# Altium schematic sheet Extra8_i2c.SchDoc
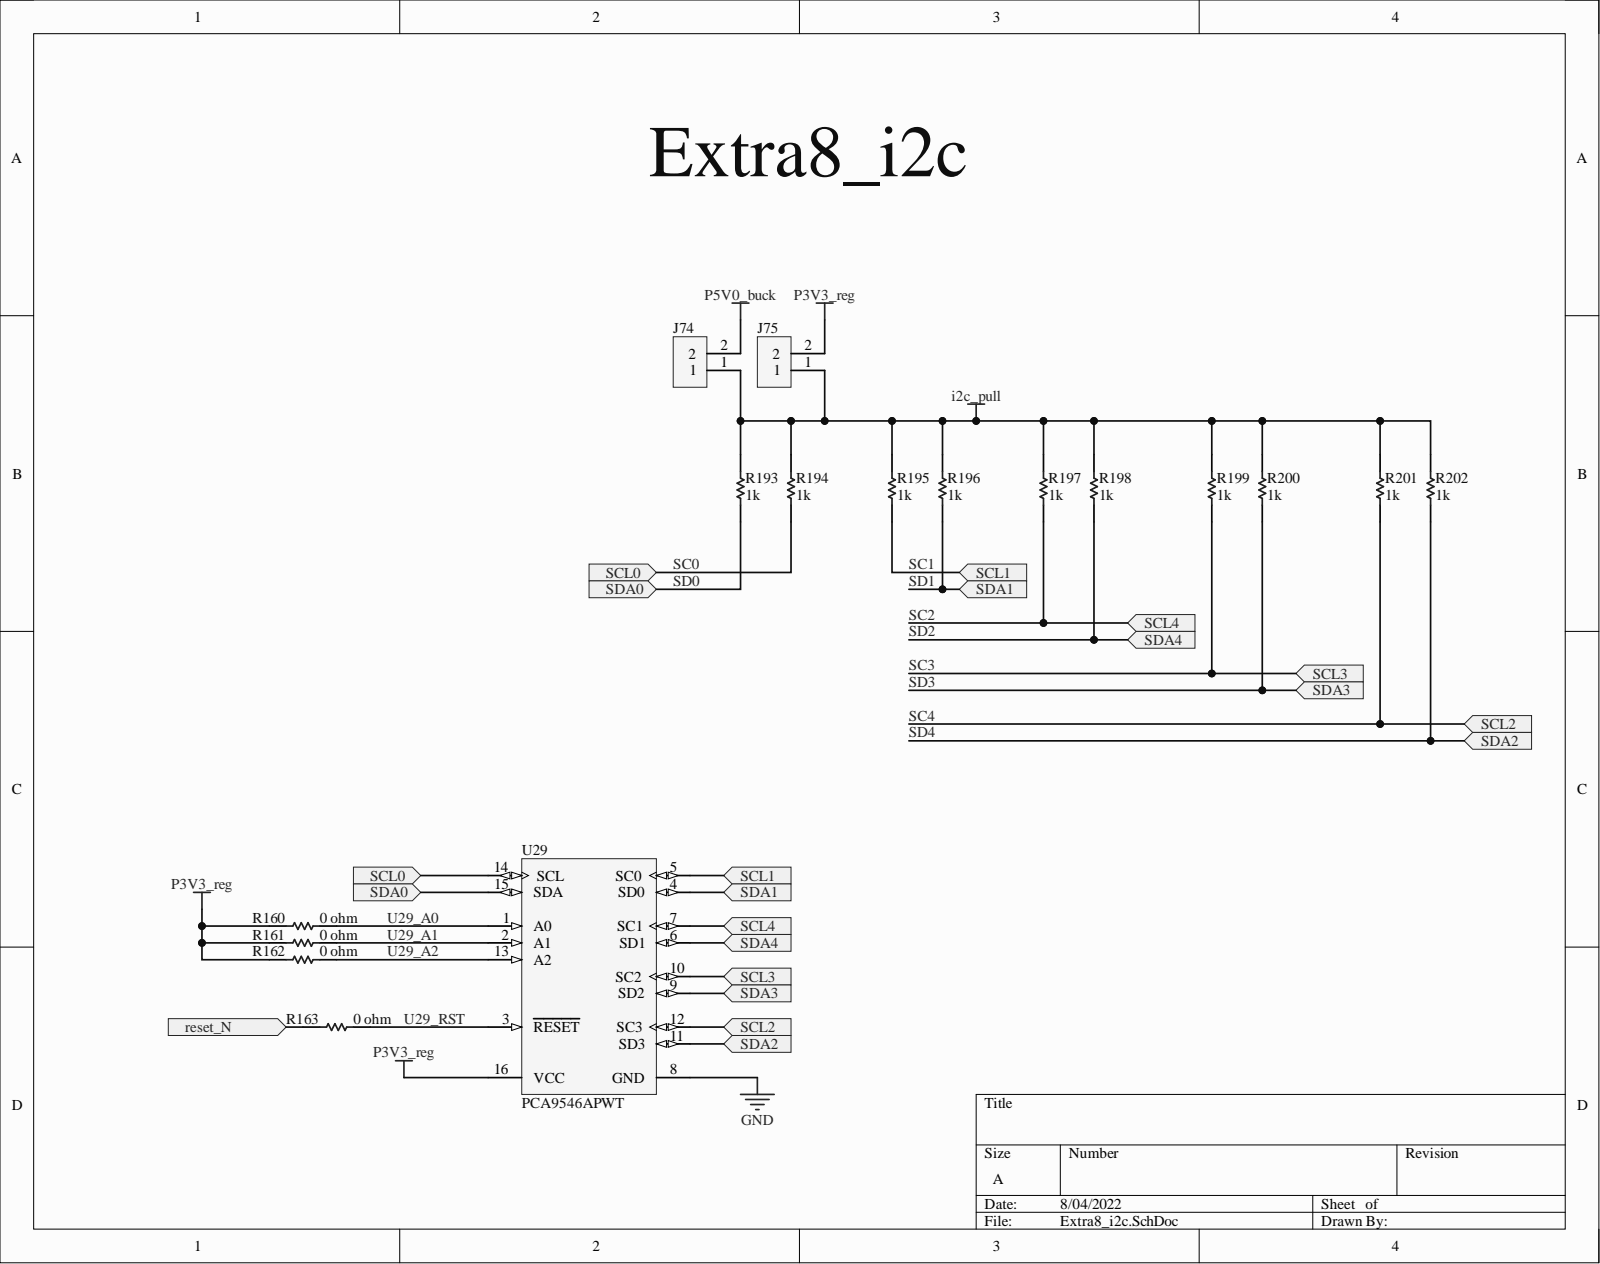
[diagram p0: Extra8_i2c.SchDoc]

## Parts
J74  (no value)  [PCB-omgsbkhp90n7lpri88og-1]
J75  (no value)  [PCB-omgsbkhp90n7lpri88og-1]
R160  0 ohm  [RESC2013X50X30LL20T20]
R161  0 ohm  [RESC2013X50X30LL20T20]
R162  0 ohm  [RESC2013X50X30LL20T20]
R163  0 ohm  [RESC2013X50X30LL20T20]
R193  1k  [PCB-inhgnewug1oc5mowfht0-1]
R194  1k  [PCB-inhgnewug1oc5mowfht0-1]
R195  1k  [PCB-inhgnewug1oc5mowfht0-1]
R196  1k  [PCB-inhgnewug1oc5mowfht0-1]
R197  1k  [PCB-inhgnewug1oc5mowfht0-1]
R198  1k  [PCB-inhgnewug1oc5mowfht0-1]
R199  1k  [PCB-inhgnewug1oc5mowfht0-1]
R200  1k  [PCB-inhgnewug1oc5mowfht0-1]
R201  1k  [PCB-inhgnewug1oc5mowfht0-1]
R202  1k  [PCB-inhgnewug1oc5mowfht0-1]
U29  PCA9546APWT  [PW16_M]

## Ports
reset_N, SCL0, SCL1, SCL2, SCL3, SCL4, SDA0, SDA1, SDA2, SDA3, SDA4

## Nets
GND: U29.8(GND)
i2c_pull: J74.1 J75.1 R193.2 R194.2 R195.2 R196.2 R197.2 R198.2 R199.2 R200.2 R201.2 R202.2
P3V3_reg: J75.2 R160.1 R161.1 R162.1 U29.16(VCC)
P5V0_buck: J74.2
reset_N: R163.1 ; port reset_N
SC0: R194.1 U29.14(SCL) ; port SCL0
SC1: R195.1 U29.5(SC0) ; port SCL1
SC2: R197.1 U29.7(SC1) ; port SCL4
SC3: R199.1 U29.10(SC2) ; port SCL3
SC4: R201.1 U29.12(SC3) ; port SCL2
SD0: R193.1 U29.15(SDA) ; port SDA0
SD1: R196.1 U29.4(SD0) ; port SDA1
SD2: R198.1 U29.6(SD1) ; port SDA4
SD3: R200.1 U29.9(SD2) ; port SDA3
SD4: R202.1 U29.11(SD3) ; port SDA2
U29_A0: R160.2 U29.1(A0)
U29_A1: R161.2 U29.2(A1)
U29_A2: R162.2 U29.13(A2)
U29_RST: R163.2 U29.3(RESET)

## Library data of the parts
J74 J75 = CMP-748fbcb73d6bab48-30: Pitch 2.54 mm, Single Row, 2pins; Component Kind: Standard; Library Name: My Personal Space
R160-R163 = CMP-1904075-2: RES SMD 0.0 OHM JUMPER 1/8W 0805; Manufacturer Part Number: CRCW08050000ZSTA; Case/Package (SI): 2012; Lead-Free Status: Contains Lead; Power Dissipation: 0.125 W; Resistance: 0.0 Ω; Resistance Tolerance: ±1%; Voltage Rating (DC): 150.0 V; Component Kind: Standard; Library Name: My Personal Space; Mounting Style: Surface Mount; Number of Pins: 2; Octopart Url: https://octopart.com/crcw08050000zsta-vishay-39388113; OctopartID: 3b91f0c21d26e456; Power Rating: 0.125 W; REACH SVHC Compliance: No SVHC; RoHS: Non-Compliant; Size-Height: 0.00045 m; Size-Length: 0.002 m; Size-Width: 0.00125 m
R193-R202 = CMP-5a329075facc85f5-3: Res Thin Film 0805 1K Ohm 0.1% 1/8W ±10ppm/°C Molded SMD SMD Punched Carrier T/R; Component Kind: Standard; Library Name: My Personal Space
U29 = CMP-4940578-2: 4-Channel I2C and SMBus Multiplexer with Reset Functions, 2.3 to 5.5 V, -40 to 85 degC, 16-pin TSSOP (PW), Green (RoHS & no Sb/Br); Lead-Free Status: Lead Free; Number of Channels: 4; Propagation Delay Max (tpd): 1e-09 s; Supply Current: 3e-06 A; Case/Package: TSSOP; Component Kind: Standard; Library Name: My Personal Space; Lifecycle Status: Active; Manufacturer Part Number: PCA9546APWT; Mounting Style: Surface Mount; Number of Pins: 16; Octopart Url: https://octopart.com/pca9546apwt-texas+instruments-711813; OctopartID: 6573ac739c90bab4; Packaging: Cut Tape (CT); REACH SVHC Compliance: No SVHC; RoHS: Compliant
